# Altium schematic sheet nrf52840_qiaa_var2.SchDoc
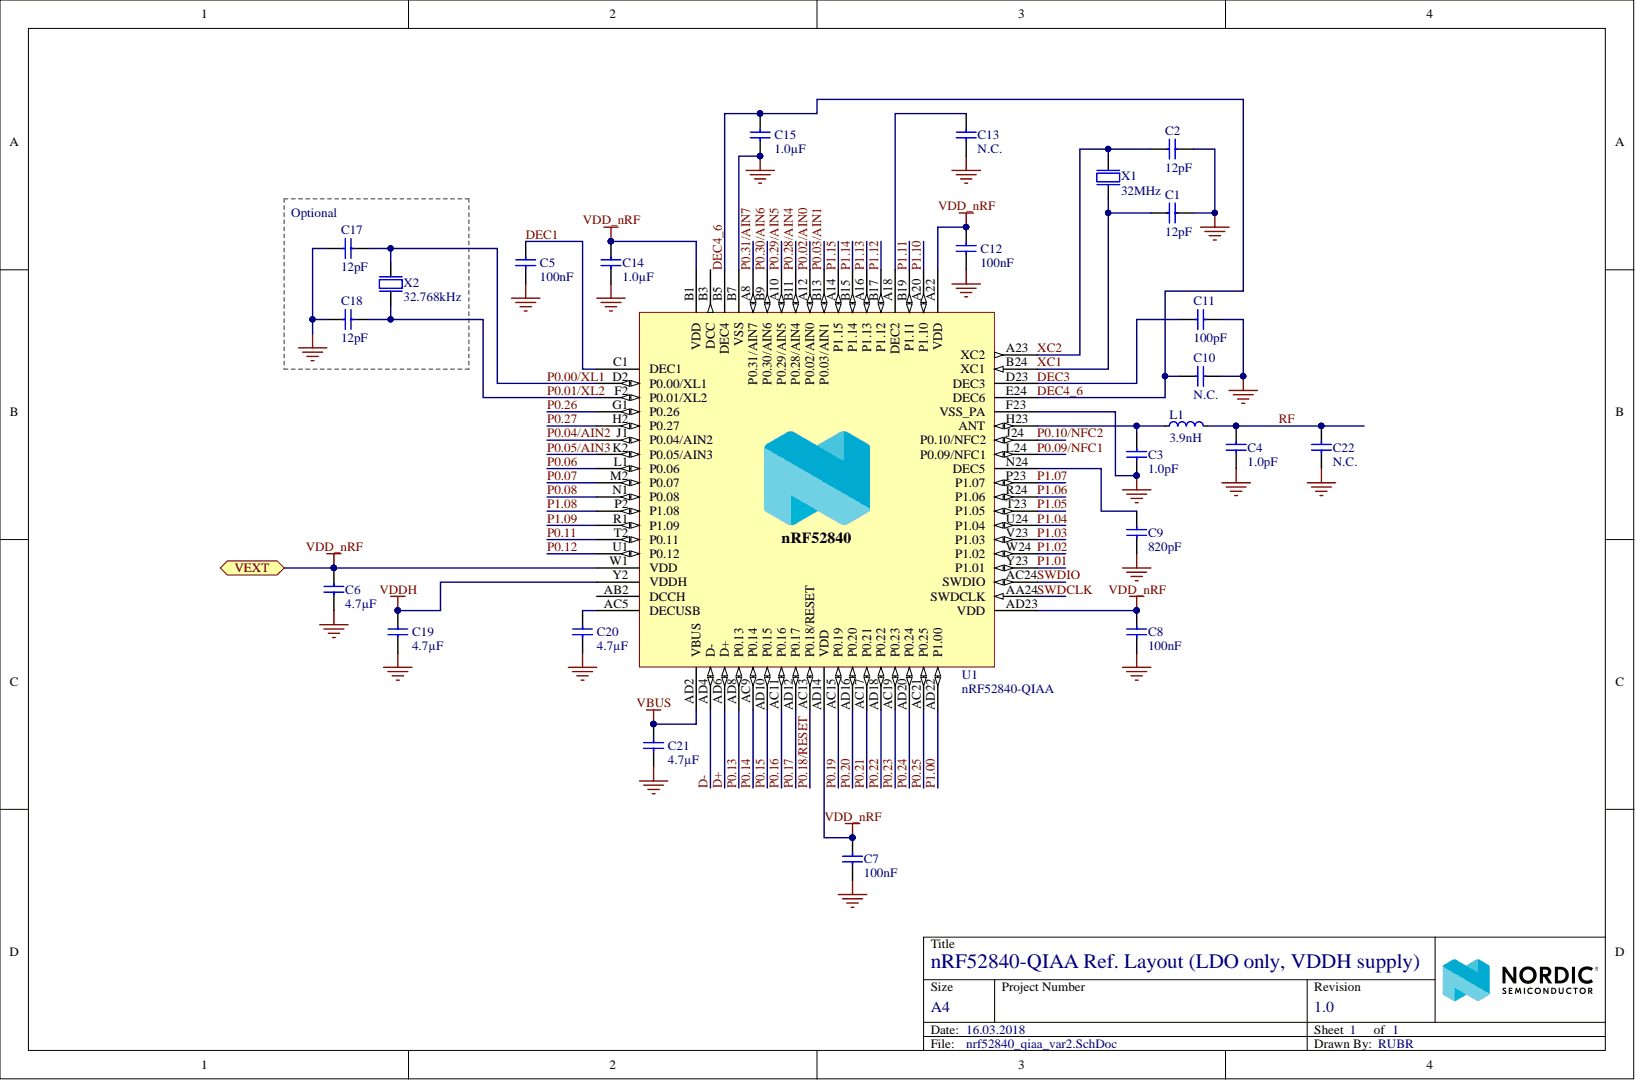
[diagram p0: nrf52840_qiaa_var2.SchDoc]

## Parts
C1  12pF  [CAPC1005X04L]
C2  12pF  [CAPC1005X04L]
C3  1.0pF  [CAPC1005X04L]
C4  1.0pF  [CAPC1005X04L]
C5  100nF  [CAPC1005X04L]
C6  4.7µF  [CAPC1608X06L]
C7  100nF  [CAPC1005X04L]
C8  100nF  [CAPC1005X04L]
C9  820pF  [CAPC1005X04L]
C10  N.C.  [CAPC1005X04L]
C11  100pF  [CAPC1005X04L]
C12  100nF  [CAPC1005X04L]
C13  N.C.  [CAPC1005X04L]
C14  1.0µF  [CAPC1608X06L]
C15  1.0µF  [CAPC1608X06L]
C17  12pF  [CAPC1005X04L]
C18  12pF  [CAPC1005X04L]
C19  4.7µF  [CAPC1608X06L]
C20  4.7µF  [CAPC1608X06L]
C21  4.7µF  [CAPC1608X06L]
C22  N.C.  [CAPC1005X04L]
L1  3.9nH  [INDC1005X04L]
U1  nRF52840-QIAA  [AQFN50P700X700X85_HS-74N]
X1  32MHz  [BT-XTAL_2016]
X2  32.768kHz  [XTAL_3215]

## Ports
VEXT

## Nets
GND: C1.2 C2.2 C3.1 C4.1 C5.2 C6.2 C7.2 C8.2 C9.2 C10.1 C11.2 C12.2 C13.2 C14.2 C15.1 C17.2 C18.2 C19.2 C20.2 C21.2 C22.2 U1.74 U1.B7(VSS) U1.F23(VSS_PA) X1.2 X1.4
VBUS: C21.1 U1.AD2(VBUS)
VDDH: C19.1 U1.Y2(VDDH)
D+: U1.AD6(D+)
D-: U1.AD4(D-)
DEC1: C5.1 U1.C1(DEC1)
DEC3: C11.1 U1.D23(DEC3)
DEC4_6: C10.2 C15.2 U1.B5(DEC4) U1.E24(DEC6)
P0.00/XL1: C17.1 U1.D2(P0.00/XL1) X2.1
P0.01/XL2: C18.1 U1.F2(P0.01/XL2) X2.2
P0.02/AIN0: U1.A12(P0.02/AIN0)
P0.03/AIN1: U1.B13(P0.03/AIN1)
P0.04/AIN2: U1.J1(P0.04/AIN2)
P0.05/AIN3: U1.K2(P0.05/AIN3)
P0.06: U1.L1(P0.06)
P0.07: U1.M2(P0.07)
P0.08: U1.N1(P0.08)
P0.09/NFC1: U1.L24(P0.09/NFC1)
P0.10/NFC2: U1.J24(P0.10/NFC2)
P0.11: U1.T2(P0.11)
P0.12: U1.U1(P0.12)
P0.13: U1.AD8(P0.13)
P0.14: U1.AC9(P0.14)
P0.15: U1.AD10(P0.15)
P0.16: U1.AC11(P0.16)
P0.17: U1.AD12(P0.17)
P0.18/RESET: U1.AC13(P0.18/RESET)
P0.19: U1.AC15(P0.19)
P0.20: U1.AD16(P0.20)
P0.21: U1.AC17(P0.21)
P0.22: U1.AD18(P0.22)
P0.23: U1.AC19(P0.23)
P0.24: U1.AD20(P0.24)
P0.25: U1.AC21(P0.25)
P0.26: U1.G1(P0.26)
P0.27: U1.H2(P0.27)
P0.28/AIN4: U1.B11(P0.28/AIN4)
P0.29/AIN5: U1.A10(P0.29/AIN5)
P0.30/AIN6: U1.B9(P0.30/AIN6)
P0.31/AIN7: U1.A8(P0.31/AIN7)
P1.00: U1.AD22(P1.00)
P1.01: U1.Y23(P1.01)
P1.02: U1.W24(P1.02)
P1.03: U1.V23(P1.03)
P1.04: U1.U24(P1.04)
P1.05: U1.T23(P1.05)
P1.06: U1.R24(P1.06)
P1.07: U1.P23(P1.07)
P1.08: U1.P2(P1.08)
P1.09: U1.R1(P1.09)
P1.10: U1.A20(P1.10)
P1.11: U1.B19(P1.11)
P1.12: U1.B17(P1.12)
P1.13: U1.A16(P1.13)
P1.14: U1.B15(P1.14)
P1.15: U1.A14(P1.15)
RF: C4.2 C22.1 L1.2
SWDCLK: U1.AA24(SWDCLK)
SWDIO: U1.AC24(SWDIO)
VDD_nRF: C6.1 C7.1 C8.1 C12.1 C14.1 U1.A22(VDD) U1.AD14(VDD) U1.AD23(VDD) U1.B1(VDD) U1.W1(VDD) ; port VEXT
XC1: C1.1 U1.B24(XC1) X1.1
XC2: C2.1 U1.A23(XC2) X1.3
NetC3_2: C3.2 L1.1 U1.H23(ANT)
NetC9_1: C9.1 U1.N24(DEC5)
NetC13_1: C13.1 U1.A18(DEC2)
NetC20_1: C20.1 U1.AC5(DECUSB)

Not connected: U1 AB2(DCCH) B3(DCC)

## Library data of the parts
C1 C2 C17 C18 = C0402-12p: Capacitor, NP0, ±2%; Database Table Name: Capacitors$; Note: 50V; Component Kind: Standard; Footprint Doc: 0402; LatestRevisionDate: 2011-05-11; Library Name: Nordic Passive DB.DbLib; Part Number: C0402-12p; Pin Count: 2; Published: 2009-05-08; Value: 12pF; Publisher: Nordic Semiconductor
C3 C4 = C0402-1.0p: Capacitor, NP0, ±5%; Database Table Name: Capacitors$; Note: 50V; Component Kind: Standard; Footprint Doc: 0402; LatestRevisionDate: 2017-02-23; Library Name: Nordic Passive DB.DbLib; Part Number: C0402-1.0p; Pin Count: 2; Published: 2009-05-08; Value: 1.0pF; Publisher: Nordic Semiconductor
C5 C7 C8 C12 = C0402-100n: Capacitor, X7R, ±10%; Database Table Name: Capacitors$; Note: 16V; Component Kind: Standard; Footprint Doc: 0402; LatestRevisionDate: 2009-11-23; Library Name: Nordic Passive DB.DbLib; Part Number: C0402-100n; Pin Count: 2; Published: 2009-05-08; Value: 100nF; Publisher: Nordic Semiconductor
C6 C20 = C0603-4µ7-6.3V-X7R: Capacitor, X7R, ±10%; Database Table Name: Capacitors$; Note: 6.3V; Component Kind: Standard; Footprint Doc: 0603; LatestRevisionDate: 2015-06-10; Library Name: Nordic Passive DB.DbLib; Part Number: C0603-4µ7-6.3V-X7R; Pin Count: 2; Published: 2015-06-10; Value: 4.7µF; Publisher: Nordic Semiconductor
C9 = C0402-820p: Capacitor, NP0, ±5%; Database Table Name: Capacitors$; Note: 50V; Component Kind: Standard; Footprint Doc: 0402; LatestRevisionDate: 2009-05-08; Library Name: Nordic Passive DB.DbLib; Part Number: C0402-820p; Pin Count: 2; Published: 2009-05-08; Value: 820pF; Publisher: Nordic Semiconductor
C10 C13 = C0402-N.C.: Not mounted; Assembly: Not mounted; Database Table Name: Capacitors$; Component Kind: Standard; Footprint Doc: 0402; LatestRevisionDate: 2009-05-08; Library Name: Nordic Passive DB.DbLib; Part Number: C0402-N.C.; Pin Count: 2; Published: 2009-05-08; Value: N.C.; Publisher: Nordic Semiconductor
C11 = C0402-100p: Capacitor, NP0, ±5%; Database Table Name: Capacitors$; Note: 50V; Component Kind: Standard; Footprint Doc: 0402; LatestRevisionDate: 2009-05-08; Library Name: Nordic Passive DB.DbLib; Part Number: C0402-100p; Pin Count: 2; Published: 2009-05-08; Value: 100pF; Publisher: Nordic Semiconductor
C14 C15 = C0603-1µ0: Capacitor, X7R, ±10%; Database Table Name: Capacitors$; Note: 16V; Component Kind: Standard; Footprint Doc: 0603; LatestRevisionDate: 2013-06-05; Library Name: Nordic Passive DB.DbLib; Part Number: C0603-1µ0; Pin Count: 2; Published: 2009-05-08; Value: 1.0µF; Publisher: Nordic Semiconductor
C19 C21 = C0603-4µ7-10V-X7S: Capacitor, X7S, ±10%; Database Table Name: Capacitors$; Note: 10V; Component Kind: Standard; Footprint Doc: 0603; LatestRevisionDate: 2016-08-15; Library Name: Nordic Passive DB.DbLib; Part Number: C0603-4µ7-10V-X7S; Pin Count: 2; Published: 2016-08-15; Value: 4.7µF; Publisher: Nordic Semiconductor
C22 = C0402-N.C.: Not mounted; Assembly: Not mounted; Database Table Name: Capacitors$; Note: Chip Cap; Component Kind: Standard; Footprint Doc: 0402; LatestRevisionDate: 2009-05-08; Library Name: Nordic Passive DB.DbLib; Part Number: C0402-N.C.; Pin Count: 2; Published: 2009-05-08; Value: N.C.; Publisher: Nordic Semiconductor
L1 = L0402-3n9: High frequency chip inductor ±5%; Database Table Name: Inductors$; Component Kind: Standard; Footprint Doc: 0402; LatestRevisionDate: 2012-09-19; Library Name: Nordic Passive DB.DbLib; Part Number: L0402-3n9; Pin Count: 2; Published: 2009-05-08; Value: 3.9nH; Publisher: Nordic Semiconductor
U1 = nRF52840-QIAAC0: Multi-protocol Bluetooth Low Energy, IEEE 802.15.4, ANT and 2.4GHz proprietary system-on-chip; Manufacturer: Nordic Semiconductor; Database Table Name: nRF Devices$; Component Kind: Standard; Footprint Doc: AQFN-73; LatestRevisionDate: 2018-02-27; Library Name: Nordic Active DB.DbLib; Manufacturer Part Number: nRF52840-QIAAC0; Part Number: nRF52840-QIAAC0; Pin Count: 73; Published: 2017-08-21; Value: nRF52840-QIAA; Publisher: Nordic Semiconductor
X1 = XTAL_SMD2016_32MHz_8pF_General: XTAL SMD 2016, 32MHz, Cl=8pF, Total Tol: ±40ppm; Database Table Name: Crystal_Osc$; Component Kind: Standard; Footprint Doc: XTAL_2016; LatestRevisionDate: 2016-08-19; Library Name: Nordic Discrete DB.DbLib; Part Number: XTAL_SMD2016_32MHz_8pF_General; Pin Count: 4; Published: 2016-08-19; Value: 32MHz; Publisher: Nordic Semiconductor
X2 = XTAL_SMD3215_32.768kHz_9pF_General: XTAL SMD 3215, 32.768kHz, Cl=9pF, Total Tol: ±50ppm; Database Table Name: Crystal_Osc$; Component Kind: Standard; Footprint Doc: XTAL_3215; LatestRevisionDate: 2016-08-19; Library Name: Nordic Discrete DB.DbLib; Part Number: XTAL_SMD3215_32.768kHz_9pF_General; Pin Count: 2; Published: 2016-08-19; Value: 32.768kHz; Publisher: Nordic Semiconductor
